# Altium schematic sheet RosCar.SchDoc
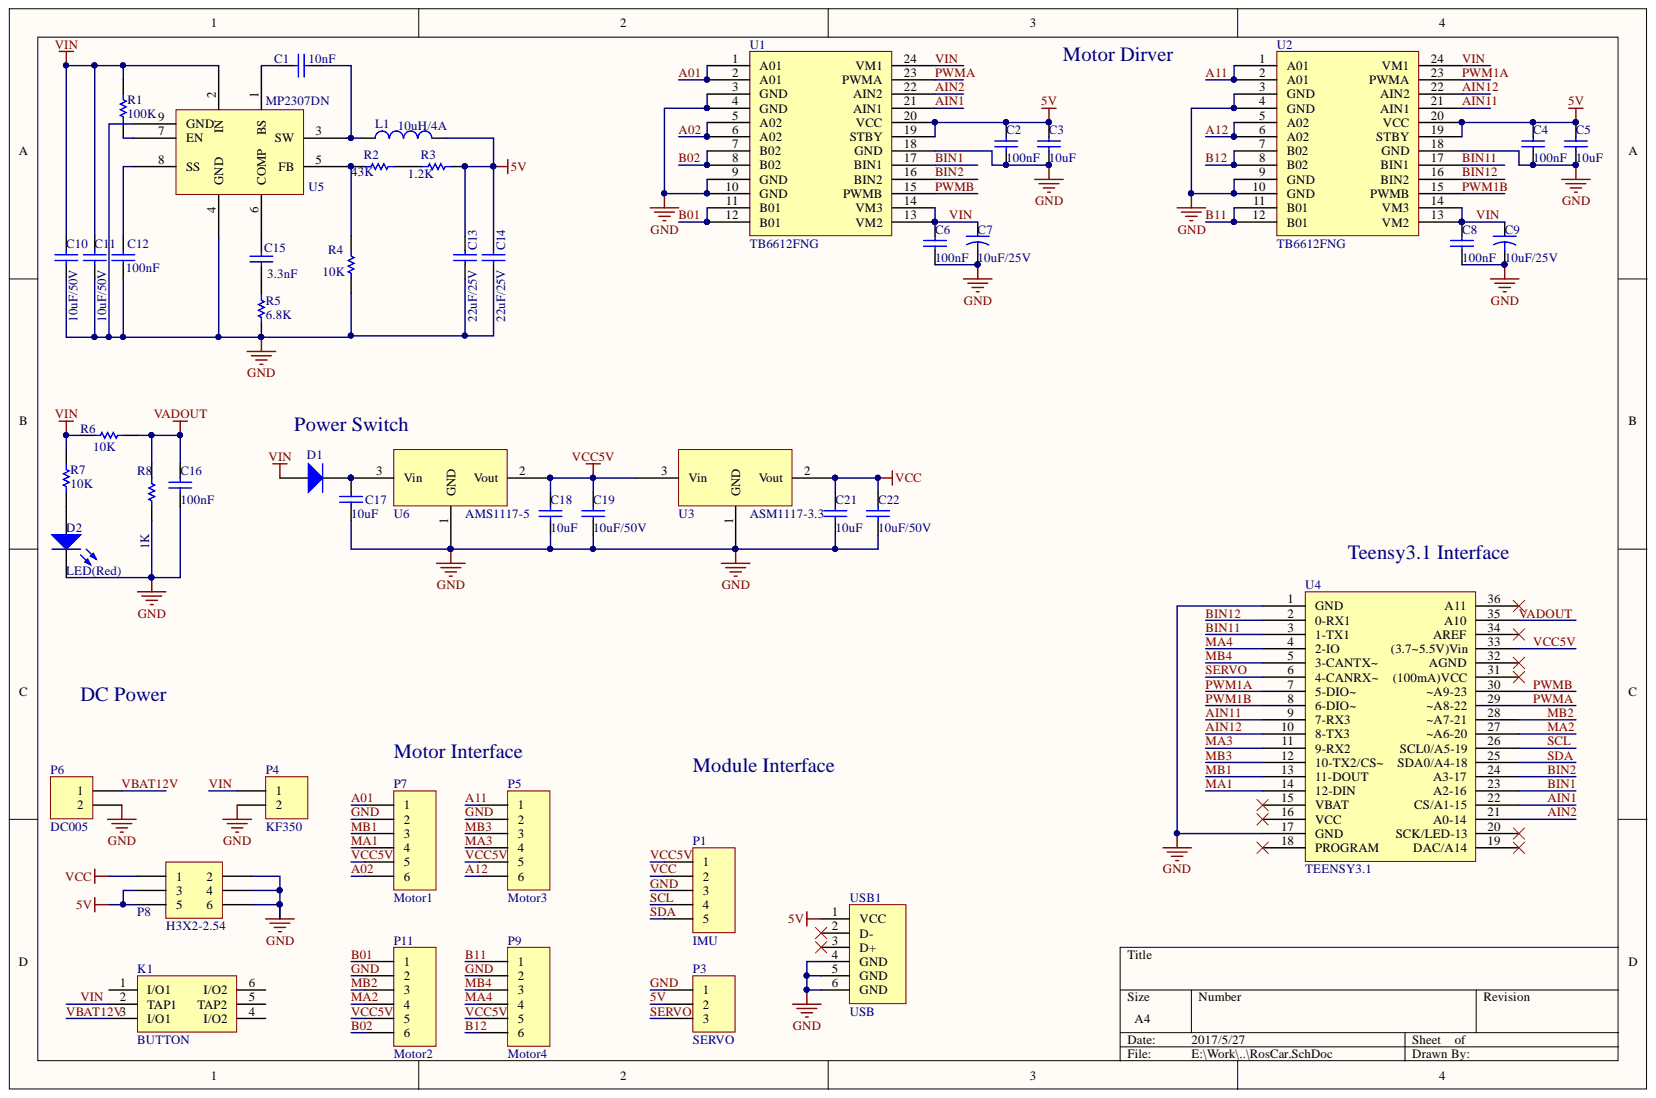
[diagram p0: RosCar.SchDoc]

## Parts
C1  10nF  [SMD-0603]
C2  100nF  [SMD-C0603]
C3  10uF  [SMD-C0603]
C4  100nF  [SMD-C0603]
C5  10uF  [SMD-C0603]
C6  100nF  [SMD-C0603]
C7  10uF/25V  [SMD-C3528]
C8  100nF  [SMD-C0603]
C9  10uF/25V  [SMD-C3528]
C10  10uF/50V  [SMD-C1206]
C11  10uF/50V  [SMD-C1206]
C12  100nF  [SMD-0603]
C13  22uF/25V  [SMD-C0805]
C14  22uF/25V  [SMD-C0805]
C15  3.3nF  [SMD-C0603]
C16  100nF  [SMD-C0603]
C17  10uF  [SMD-C0603]
C18  10uF  [SMD-C0603]
C19  10uF/50V  [SMD-C1206]
C21  10uF  [SMD-C0603]
C22  10uF/50V  [SMD-C1206]
D1  IN5819  [SOD123]  (value not drawn)
D2  LED(Red)  [SMD-LED0805]
K1  BUTTON
L1  10uH/4A  [SMD-CDRH74]
P1  IMU  [DIP-5P254]
P3  SERVO  [DIP-3P254]
P4  KF350  [DIP-KF3502P]
P5  Motor3  [DIP-XH6P254]
P6  DC005  [DC005]
P7  Motor1  [DIP-XH6P254]
P8  H3X2-2.54  [DIP-3X2P254]
P9  Motor4  [DIP-XH6P254]
P11  Motor2  [DIP-XH6P254]
R1  100K  [SMD-0603]
R2  43K  [SMD-0603]
R3  1.2K  [SMD-0603]
R4  10K  [SMD-0603]
R5  6.8K  [SMD-0603]
R6  10K  [SMD-0603]
R7  10K  [SMD-0603]
R8  1K  [SMD-0603]
U1  TB6612FNG  [SSOP24]
U2  TB6612FNG  [SSOP24]
U3  ASM1117-3.3  [SOT223]
U4  TEENSY3.1
U5  MP2307DN  [SOP-8-GND]
U6  AMS1117-5  [SOT223]
USB1  USB

## Nets
5V: C2.1 C3.1 C4.1 C5.1 C13.2 C14.2 L1.2 P3.2 P8.3 P8.5 R3.1 U1.19(STBY) U1.20(VCC) U2.19(STBY) U2.20(VCC) USB1.1(VCC)
A01: P7.1 U1.1(A01) U1.2(A01)
A02: P7.6 U1.5(A02) U1.6(A02)
A11: P5.1 U2.1(A01) U2.2(A01)
A12: P5.6 U2.5(A02) U2.6(A02)
AIN1: U1.21(AIN1) U4.22(CS/A1-15)
AIN2: U1.22(AIN2) U4.21(A0-14)
AIN11: U2.21(AIN1) U4.9(7-RX3)
AIN12: U2.22(AIN2) U4.10(8-TX3)
B01: P11.1 U1.11(B01) U1.12(B01)
B02: P11.6 U1.7(B02) U1.8(B02)
B11: P9.1 U2.11(B01) U2.12(B01)
B12: P9.6 U2.7(B02) U2.8(B02)
BIN1: U1.17(BIN1) U4.23(A2-16)
BIN2: U1.16(BIN2) U4.24(A3-17)
BIN11: U2.17(BIN1) U4.3(1-TX1)
BIN12: U2.16(BIN2) U4.2(0-RX1)
GND: C2.2 C3.2 C4.2 C5.2 C6.2 C7.2 C8.2 C9.2 C10.1 C11.1 C12.1 C13.1 C14.1 C16.2 C17.1 C18.1 C19.1 C21.1 C22.1 D2.2 P1.3 P3.1 P4.2 P5.2 P6.2 P7.2 P8.2 P8.4 P8.6 P9.2 P11.2 R4.1 R5.1 R8.1 U1.3(GND) U1.4(GND) U1.9(GND) U1.10(GND) U1.18(GND) U2.3(GND) U2.4(GND) U2.9(GND) U2.10(GND) U2.18(GND) U3.1 U4.1(GND) U4.17(GND) U5.4(GND) U5.9(GND) U6.1 USB1.4(GND) USB1.5(GND) USB1.6(GND)
MA1: P7.4 U4.14(12-DIN)
MA2: P11.4 U4.27(~A6-20)
MA3: P5.4 U4.11(9-RX2)
MA4: P9.4 U4.4(2-IO)
MB1: P7.3 U4.13(11-DOUT)
MB2: P11.3 U4.28(~A7-21)
MB3: P5.3 U4.12(10-TX2/CS~)
MB4: P9.3 U4.5(3-CANTX~)
PWM1A: U2.23(PWMA) U4.7(5-DIO~)
PWM1B: U2.15(PWMB) U4.8(6-DIO~)
PWMA: U1.23(PWMA) U4.29(~A8-22)
PWMB: U1.15(PWMB) U4.30(~A9-23)
SCL: P1.4 U4.26(SCL0/A5-19)
SDA: P1.5 U4.25(SDA0/A4-18)
SERVO: P3.3 U4.6(4-CANRX~)
VADOUT: C16.1 R6.2 R8.2 U4.35(A10)
VBAT12V: K1.3(I/O1) P6.1
VCC: C21.2 C22.2 P1.2 P8.1 U3.2
VCC5V: C18.2 C19.2 P1.1 P5.5 P7.5 P9.5 P11.5 U3.3 U4.33((3.7~5.5V)Vin) U6.2
VIN: C6.1 C7.1 C8.1 C9.1 C10.2 C11.2 D1.2 K1.2(TAP1) P4.1 R1.2 R6.1 R7.2 U1.13(VM2) U1.14(VM3) U1.24(VM1) U2.13(VM2) U2.14(VM3) U2.24(VM1) U5.2(IN)
NetC1_1: C1.1 L1.1 U5.3(SW)
NetC1_2: C1.2 U5.1(BS)
NetC12_2: C12.2 U5.8(SS)
NetC15_1: C15.1 R5.2
NetC15_2: C15.2 U5.6(COMP)
NetC17_2: C17.2 D1.1 U6.3
NetD2_1: D2.1 R7.1
NetR1_1: R1.1 U5.7(EN)
NetR2_1: R2.1 R3.2
NetR2_2: R2.2 R4.2 U5.5(FB)

Not connected: K1 1(I/O1) 4(I/O2) 5(TAP2) 6(I/O2); U4 15(VBAT) 16(VCC) 18(PROGRAM) 19(DAC/A14) 20(SCK/LED-13) 31((100mA)VCC) 32(AGND) 34(AREF) 36(A11); USB1 2(D-) 3(D+)

## Library data of the parts
C1-C6 C8 C10-C18 C21 = Cap Semi: Capacitor (Semiconductor SIM Model); Component Kind: Standard; LatestRevisionDate: 29-May-2009; LatestRevisionNote: IPC-7351 Footprint Added.; Library Name: Miscellaneous Devices.IntLib; PackageDescription: Chip Capacitor; PackageReference: C1206; Published: 8-Jun-2000; Signal Integrity: Cap Semi; Simulation: CAP; Publisher: Altium Limited
  C1: Value: 10nF
  C2 C4 C6 C8 C12 C16: Value: 100nF
  C3 C5 C17 C18 C21: Value: 10uF
  C10 C11: Value: 10uF/50V
  C13 C14: Value: 22uF/25V
  C15: Value: 3.3nF
C7 C9 = Cap2: Capacitor; Component Kind: Standard; Library Name: Miscellaneous Devices.IntLib; PackageDescription: Capacitor; 2 Leads; PackageReference: CAPR5-4X5; Published: 23-Sep-2002; Value: 10uF/25V; Publisher: Altium Limited
C19 C22 = Cap: Capacitor; Component Kind: Standard; LatestRevisionDate: 17-Jul-2002; LatestRevisionNote: Re-released for DXP Platform.; Library Name: Miscellaneous Devices.IntLib; PackageDescription: Radial Cap, Thru-Hole; 2 Leads; 0.3 in Pin Spacing; PackageReference: RAD-0.3; Published: 8-Jun-2000; Signal Integrity: Cap; Simulation: CAP; Value: 10uF/50V; Publisher: Altium Limited
D1 = Diode 1N4002: 1 Amp General Purpose Rectifier; Code_JEDEC: DO-204-AL; Component Kind: Standard; ComponentLink1Description: Manufacturer Link; ComponentLink1URL: http://www.fairchildsemi.com/; ComponentLink2Description: Datasheet; ComponentLink2URL: http://www.fairchildsemi.com/ds/1N%2F1N4001.pdf; DatasheetDocument: 1998; LatestRevisionDate: 17-Jul-2002; LatestRevisionNote: Re-released for DXP Platform.; Library Name: Miscellaneous Devices.IntLib; PackageDocument: Aug-1999; PackageReference: DO-41; Published: 8-Jun-2000; Publisher: Altium Limited
D2 = LED0: Typical INFRARED GaAs LED; Component Kind: Standard; LatestRevisionDate: 08-Jul-2005; LatestRevisionNote: Mech Layer 1 removed.; Library Name: Miscellaneous Devices.IntLib; PackageDescription: LED; 2 Leads; PackageReference: LED-0; Published: 8-Jun-2000; Simulation: LED0; Publisher: Altium Limited
K1 = BUTTON: 6Pin Button; Component Kind: Standard; Library Name: kevinSchLib.SchLib
L1 = Inductor: Code_IEC: 1005; Code_JEITA: 0402; Component Kind: Standard; Description: Inductor; LatestRevisionDate: 29-May-2009; LatestRevisionNote: IPC-7351 Footprint Added.; Library Name: Miscellaneous Devices.IntLib; PackageDescription: Chip Inductor; PackageReference: 0402-A; Published: 8-Jun-2000; Signal Integrity: Inductor; Simulation: INDUCTOR; Value: 10uH/4A; Publisher: Altium Limited
P1 = Header 5: Header, 5-Pin; Component Kind: Standard; LatestRevisionDate: 17-Jul-2002; LatestRevisionNote: Re-released for DXP Platform.; Library Name: Miscellaneous Connectors.IntLib; Signal Integrity: Connector; Publisher: Altium Limited
P3 = Header 3: Header, 3-Pin; Component Kind: Standard; LatestRevisionDate: 17-Jul-2002; LatestRevisionNote: Re-released for DXP Platform.; Library Name: Miscellaneous Connectors.IntLib; Signal Integrity: Connector; Publisher: Altium Limited
P4 P6 = Header 2: Header, 2-Pin; Component Kind: Standard; LatestRevisionDate: 17-Jul-2002; LatestRevisionNote: Re-released for DXP Platform.; Library Name: Miscellaneous Connectors.IntLib; Signal Integrity: Connector; Publisher: Altium Limited
P5 P7 P9 P11 = Header 6: Header, 6-Pin; Component Kind: Standard; LatestRevisionDate: 17-Jul-2002; LatestRevisionNote: Re-released for DXP Platform.; Library Name: Miscellaneous Connectors.IntLib; Publisher: Altium Limited
P8 = Header 3X2: Header, 3-Pin, Dual row; Component Kind: Standard; LatestRevisionDate: 17-Jul-2002; LatestRevisionNote: Re-released for DXP Platform.; Library Name: Miscellaneous Connectors.IntLib; Publisher: Altium Limited
R1-R8 = Res Semi: Semiconductor Resistor; Component Kind: Standard; LatestRevisionDate: 17-Jul-2002; LatestRevisionNote: Re-released for DXP Platform.; Library Name: Miscellaneous Devices.IntLib; PackageDescription: Axial Device, Thru-Hole; 2 Leads; 0.5 in Pin Spacing; PackageReference: AXIAL-0.5; Published: 8-Jun-2000; Signal Integrity: Res Semi; Simulation: RES; Publisher: Altium Limited
  R1: Value: 100K
  R2: Value: 43K
  R3: Value: 1.2K
  R4 R6 R7: Value: 10K
  R5: Value: 6.8K
  R8: Value: 1K
U1 U2 = TB6612FNG: Driver IC for Dual DC motor; Component Kind: Standard; Library Name: kevinSchLib.SchLib
U3 U6 = ASM1117: Component Kind: Standard; Library Name: kevinSchLib.SchLib
U4 = TEENSY3.1: TEENSY3.1 Borad; Component Kind: Standard; Library Name: kevinSchLib.SchLib
U5 = MP2307DN: 3A, 23V, 340KHz Synchronous Step-Down Converter; Component Kind: Standard; Library Name: kevinSchLib.SchLib
USB1 = USB: USBA; Component Kind: Standard; Library Name: kevinSchLib.SchLib
